# Altium schematic sheet 2_Flyback_regulator.SchDoc
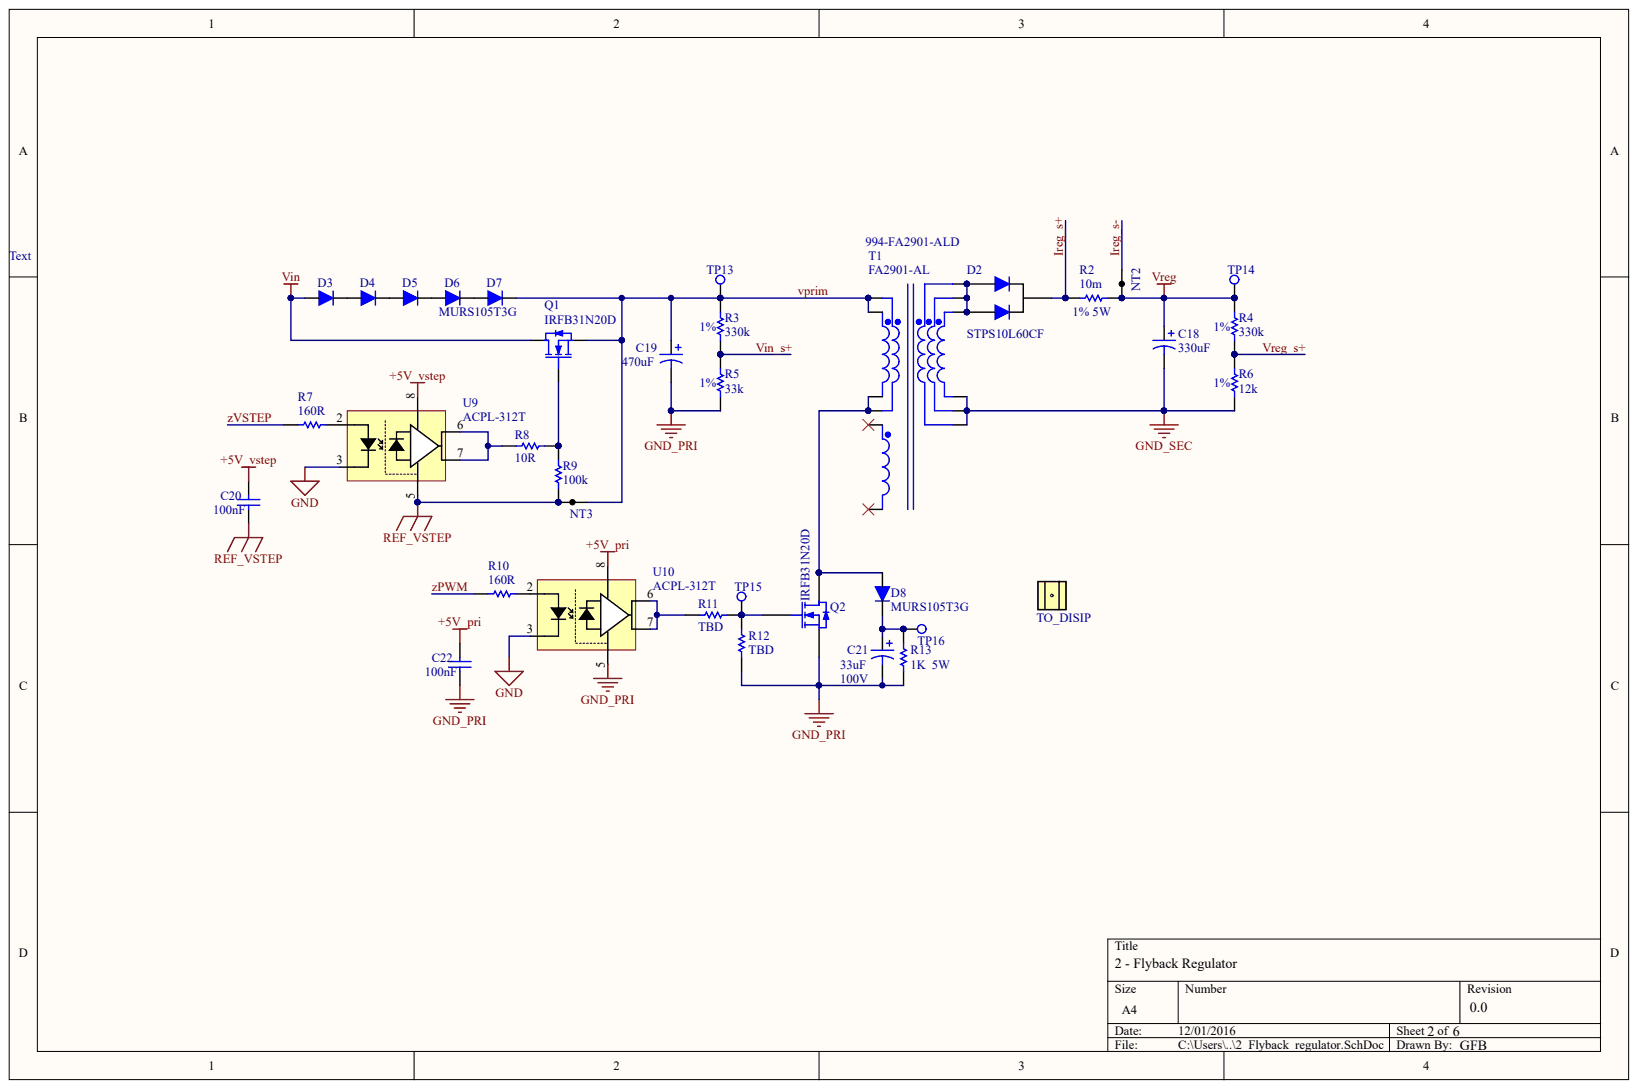
[diagram p0: 2_Flyback_regulator.SchDoc]

## Parts
C18  value 330uF  (hidden comment: Cap Pol1)
C19  value 470uF  (hidden comment: Cap Pol1)
C20  value 100nF  (hidden comment: Cap)
C21  100V  value 33uF
C22  value 100nF  (hidden comment: Cap)
D2  STPS10L60CF
D3  MURS105T3G
D4  MURS105T3G
D5  MURS105T3G
D6  MURS105T3G
D7  MURS105T3G
D8  MURS105T3G
NT2  NT
NT3  NT
Q1  IRFB31N20D
Q2  IRFB31N20D
R2  1% 5W  value 10m  Power: 5W
R3  1%  value 330k
R4  1%  value 330k
R5  1%  value 33k
R6  1%  value 12k
R7  value 160R  (hidden comment: Res1)
R8  value 10R  (hidden comment: Res1)
R9  value 100k  (hidden comment: Res1)
R10  value 160R  (hidden comment: Res1)
R11  value TBD  (hidden comment: Res1)
R12  value TBD  (hidden comment: Res1)
R13  value 1K  Power: 5W  (hidden comment: Res1)
T1  FA2901-AL
TD1  TO_DISIP
TP13  TestPoint  (value not drawn)
TP14  TestPoint  (value not drawn)
TP15  TestPoint  (value not drawn)
TP16  TestPoint  (value not drawn)
U9  ACPL-312T
U10  ACPL-312T

## Nets
+5V_PRI: C22.2 U10.8
+5V_VSTEP: C20.2 U9.8
GND: U9.3 U10.3
GND_PRI: C19.2 C21.2 C22.1 Q2.3 R5.2 R12.1 R13.1 U10.5
GND_SEC: C18.2 R6.2 T1.7 T1.8 T1.9
REF_VSTEP: C20.1 NT3.2 R9.2 U9.5
VIN: D3.A Q1.2
VREG: C18.1 NT2.1 R2.1 R4.1 TP14.1
IREG_S+: D2.2 R2.2
IREG_S-: NT2.2
VIN_S+: R3.2 R5.1
VPRIM: C19.1 D7.K NT3.1 Q1.3 R3.1 T1.1 T1.2 TP13.1
VREG_S+: R4.2 R6.1
ZPWM: R10.2
ZVSTEP: R7.2
NetC21_1: C21.1 D8.K R13.2 TP16.1
NetD2_1: D2.1 D2.3 T1.10 T1.11 T1.12
NetD3_K: D3.K D4.A
NetD4_K: D4.K D5.A
NetD5_K: D5.K D6.A
NetD6_K: D6.K D7.A
NetD8_A: D8.A Q2.2 T1.3 T1.4
NetQ1_1: Q1.1 R8.1 R9.1
NetQ2_1: Q2.1 R11.1 R12.2 TP15.1
NetR7_1: R7.1 U9.2
NetR8_2: R8.2 U9.6 U9.7
NetR10_1: R10.1 U10.2
NetR11_2: R11.2 U10.6 U10.7

Not connected: T1 5 6

## Library data of the parts
R8 R9 R11 R12 = (no symbol name): Power: 5W; Published: 8-Jun-2000; LatestRevisionDate: 17-Jul-2002; LatestRevisionNote: Re-released for DXP Platform.; PackageReference: AXIAL-0.3; PackageDescription: Axial Device, Thru-Hole; 2 Leads; 0.3 in Pin Spacing; Publisher: Altium Limited
  R8 R9: ClassName: Vstep
  R11 R12: ClassName: pwm
T1 = (no symbol name): Manufacturer: Coilcraft; Manufacturer Part Number: FA2901-ALD; VendorNumber: 5822; Supplier 1: Mouser; Supplier Part Number 1: 994-FA2901-ALD; RoHS: RoHS Compliant; Category: Transformers Audio & Signal; Stock 1: 0; Pricing 1: 175=5.17, 350=4.75, 875=4.43 (AUD); Packaging: Reel; Product: Flyback; Series: FA2901; Brand: Coilcraft; RoHS - Mouser: Y; Minimum Order Quantity: 175; Reeling: True; ComponentLink1URL: http://www.mouser.com/ds/2/597/fa2677-463482.pdf; ComponentLink1Description: http://www.mouser.com/ds/2/597/fa2677-463482.pdf
TP13-TP16 = (no symbol name): RS: 262-2185
  TP15: ClassName: pwm
  TP16: ClassName: snubber
C18-C22 D2-D8 NT2 NT3 Q1 Q2 R2-R7 R10 R13 TD1 U9 U10 = (no symbol name)
  C18: Published: 8-Jun-2000; LatestRevisionDate: 17-Jul-2002; LatestRevisionNote: Re-released for DXP Platform.; PackageReference: RB7.6-15; PackageDescription: Polarized Capacitor (Radial); 2 Leads
  C19: Published: 8-Jun-2000; LatestRevisionDate: 17-Jul-2002; LatestRevisionNote: Re-released for DXP Platform.; PackageReference: RB7.6-15; PackageDescription: Polarized Capacitor (Radial); 2 Leads; ClassName: Vstep
  C20: Published: 8-Jun-2000; LatestRevisionDate: 17-Jul-2002; LatestRevisionNote: Re-released for DXP Platform.; PackageReference: RAD-0.3; PackageDescription: Radial Cap, Thru-Hole; 2 Leads; 0.3 in Pin Spacing; ClassName: Vstep
  C21: Published: 8-Jun-2000; LatestRevisionDate: 17-Jul-2002; LatestRevisionNote: Re-released for DXP Platform.; PackageReference: RB7.6-15; PackageDescription: Polarized Capacitor (Radial); 2 Leads; ClassName: snubber
  C22: Published: 8-Jun-2000; LatestRevisionDate: 17-Jul-2002; LatestRevisionNote: Re-released for DXP Platform.; PackageReference: RAD-0.3; PackageDescription: Radial Cap, Thru-Hole; 2 Leads; 0.3 in Pin Spacing; ClassName: pwm
  D3-D7 U9: ClassName: Vstep
  D8: ClassName: snubber
  Q1: Published: 8-Jun-2000; LatestRevisionDate: 17-Jul-2002; LatestRevisionNote: Re-released for DXP Platform.; PackageVersion: 1995/1996; PackageReference: E3; PackageDescription: TO, Flat Index; 3 In-Line, Axial Leads; Body Dia. 4.6mm; Lead Dia. 0.5 mm (max); ClassName: Vstep
  Q2: Published: 8-Jun-2000; LatestRevisionDate: 17-Jul-2002; LatestRevisionNote: Re-released for DXP Platform.; PackageVersion: 1995/1996; PackageReference: E3; PackageDescription: TO, Flat Index; 3 In-Line, Axial Leads; Body Dia. 4.6mm; Lead Dia. 0.5 mm (max)
  R2-R6: Published: 8-Jun-2000; LatestRevisionDate: 17-Jul-2002; LatestRevisionNote: Re-released for DXP Platform.; PackageReference: AXIAL-0.3; PackageDescription: Axial Device, Thru-Hole; 2 Leads; 0.3 in Pin Spacing
  R7: Published: 8-Jun-2000; LatestRevisionDate: 17-Jul-2002; LatestRevisionNote: Re-released for DXP Platform.; PackageReference: AXIAL-0.3; PackageDescription: Axial Device, Thru-Hole; 2 Leads; 0.3 in Pin Spacing; ClassName: Vstep
  R10: Published: 8-Jun-2000; LatestRevisionDate: 17-Jul-2002; LatestRevisionNote: Re-released for DXP Platform.; PackageReference: AXIAL-0.3; PackageDescription: Axial Device, Thru-Hole; 2 Leads; 0.3 in Pin Spacing; ClassName: pwm
  R13: Published: 8-Jun-2000; LatestRevisionDate: 17-Jul-2002; LatestRevisionNote: Re-released for DXP Platform.; PackageReference: AXIAL-0.3; PackageDescription: Axial Device, Thru-Hole; 2 Leads; 0.3 in Pin Spacing; ClassName: snubber
  U10: ClassName: pwm
  C18-C22 Q1 Q2 R2-R7 R10 R13: Publisher: Altium Limited
  U9 U10: HelpURL: C:\Users\Gonzalo\GoogleDrive\UC3M\Flyback_board\3_datasheets\opto_ACPL-312T-000E.pdf
